# Altium schematic sheet Storage.SchDoc
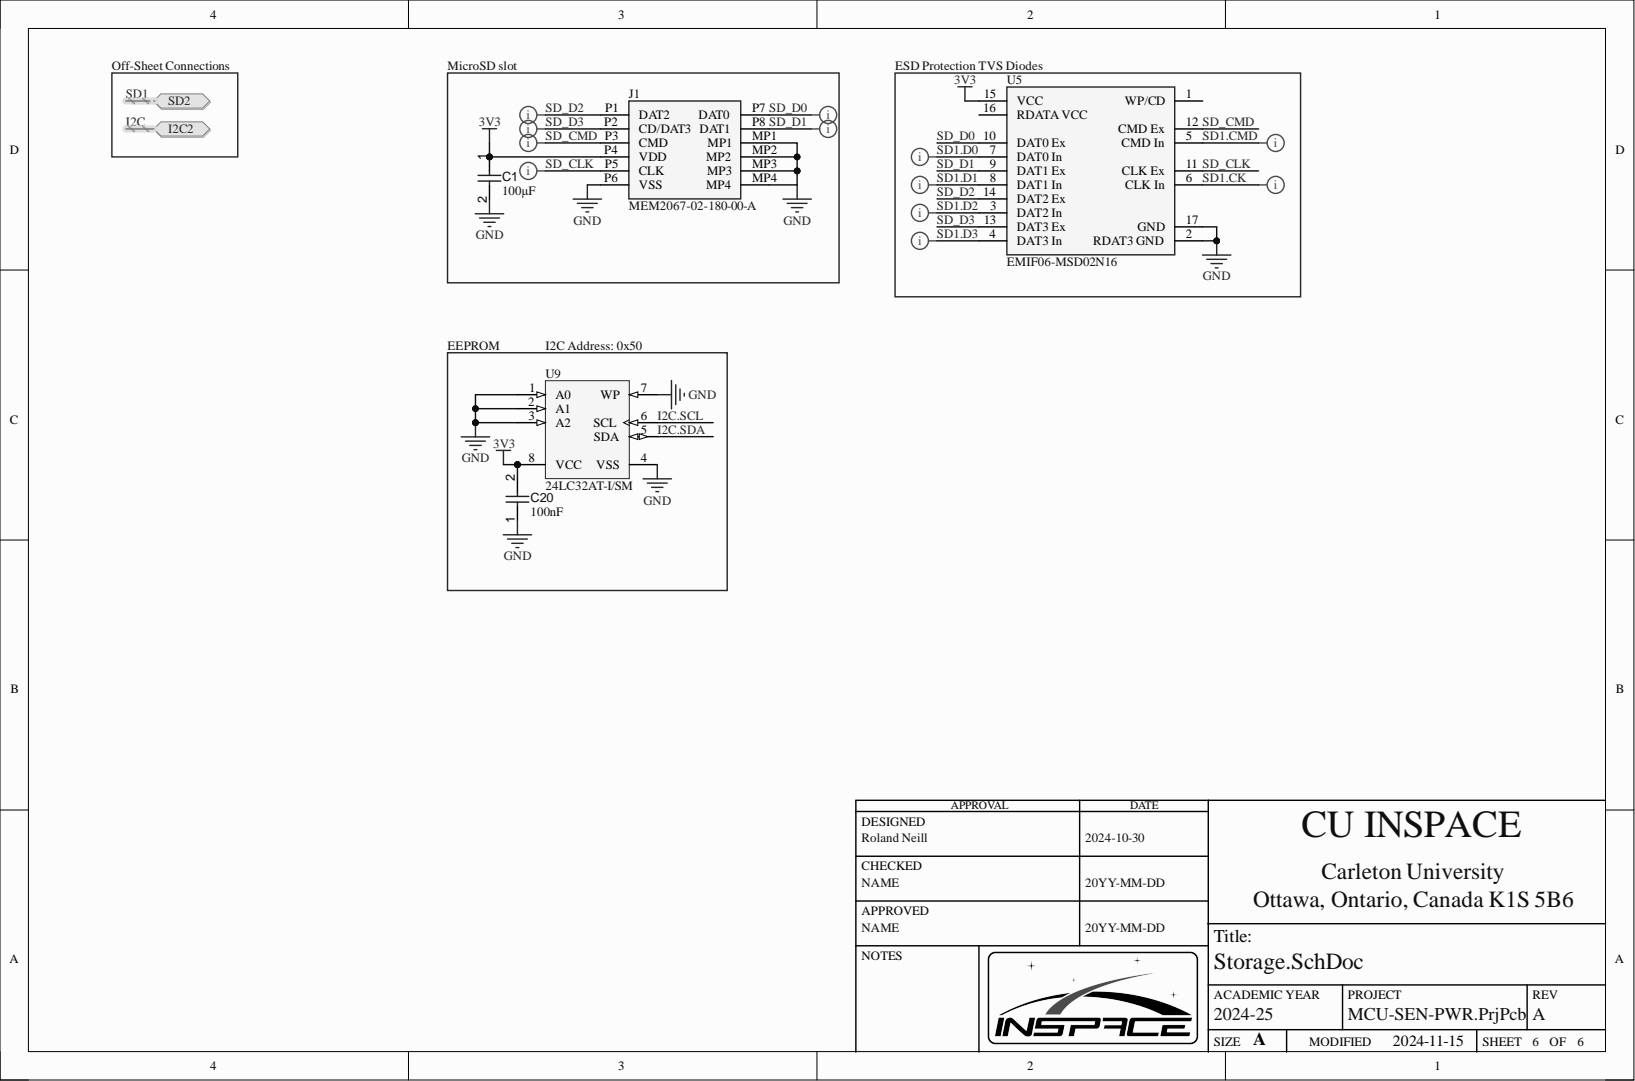
[diagram p0: Storage.SchDoc]

## Parts
C1  value 100µF  [CAPC0805(2012)145_L]  (hidden comment: Capacitor 100µF +/-20% 6.3V 0805)
C20  value 100nF  [CAPC1005X56X25LL10T15]  (hidden comment: Capacitor 0.1 uF +/- 5% 16 V 0402)
J1  MEM2067-02-180-00-A  [MEM2067-02-180-00-A-Footprint-1]
U5  EMIF06-MSD02N16  [FP-EMIF06-MSD02N16-MFG]
U9  24LC32AT-I/SM  [SOIJ-SM8_N]

## Ports
I2C2, I2C2.SCL, I2C2.SDA, SD2, SD2.CK, SD2.CMD, SD2.D0, SD2.D1, SD2.D2, SD2.D3, SD2.D[3..0]

## Nets
3V3: C1.1 C20.2 J1.P4(VDD) U5.15(VCC) U9.8(VCC)
GND: C1.2 C20.1 J1.MP1 J1.MP2 J1.MP3 J1.MP4 J1.P6(VSS) U5.2(RDAT3_GND) U5.17(GND) U9.1(A0) U9.2(A1) U9.3(A2) U9.4(VSS) U9.7(WP)
I2C.SCL: U9.6(SCL) ; port I2C2
I2C.SDA: U9.5(SDA) ; port I2C2
SD1.CK: U5.6(CLK_In) ; port SD2
SD1.CMD: U5.5(CMD_In) ; port SD2
SD1.D0: U5.7(DAT0_In) ; port SD2  [also labelled SD1.D]
SD1.D1: U5.8(DAT1_In) ; port SD2  [also labelled SD1.D]
SD1.D2: U5.3(DAT2_In) ; port SD2  [also labelled SD1.D]
SD1.D3: U5.4(DAT3_In) ; port SD2  [also labelled SD1.D]
SD_CLK: J1.P5(CLK) U5.11(CLK_Ex)
SD_CMD: J1.P3(CMD) U5.12(CMD_Ex)
SD_D0: J1.P7(DAT0) U5.10(DAT0_Ex)
SD_D1: J1.P8(DAT1) U5.9(DAT1_Ex)
SD_D2: J1.P1(DAT2) U5.14(DAT2_Ex)
SD_D3: J1.P2(CD/DAT3) U5.13(DAT3_Ex)

Not connected: U5 1(WP/CD) 16(RDATA_VCC)

## Library data of the parts
C1 = CMP-001-00054-4: Chip Capacitor, 100µF +/-20%, 6.3V, 0805, Thickness 1.45 mm; Tolerance: 10%; Case/Package: 0805; Component Kind: Standard; Max Operating Temperature: 85°C; Min Operating Temperature: -55°C; Mounting Technology: SMT; Pins: 2; Voltage Rating: 6.3V; Library Name: Americas- CU InSpace-  Carleton University
C20 = CMP-001-00001-6: Chip Capacitor, 0.1 uF, +/- 5%, 16 V, 0402 (1005 Metric); Capacitor Type: Ceramic; Voltage Rating: 16V; Case/Package: 0402; Component Kind: Standard; Max Operating Temperature: 125°C; Min Operating Temperature: -55°C; Mounting Technology: SM; Pins: 2; RoHS Compliant: True; Tolerance: 5%; Library Name: Americas- CU InSpace-  Carleton University
J1 = CMP-002-00088-3: PCB Connector, 8 Contact(s), 1 Row(s), Male, Right Angle, 0.04 inch Pitch, Surface Mount Terminal; Component Kind: Standard; Connector Type: PCB; Contact Finish - Mating: GOLD FLASH OVER NICKEL (50); Contact Finish - Termination: Tin (Sn) - with Nickel (Ni) barrier; Contact Material: Copper Alloy; JESD-609 Code: e3; Reach SVHC Compliant: Yes; RoHS Compliant: Yes; Library Name: Americas- CU InSpace-  Carleton University
U5 = CMP-031-00006-1: Data Line Filter, 1 Function(s), 16 Pin(s), Plastic; Channels: 1; Component Kind: Standard; Filter Type: DATA LINE FILTER; Height: 0.55mm; JESD-609 Code: e4; Length: 3.55mm; Max Operating Temperature: 85°C; Min Operating Temperature: -30°C; Mounting Technology: Surface Mount; Package Material: Plastic; Packaging: Tape and Reel; Pins: 16; Reach SVHC Compliant: Yes; RoHS Compliant: Yes; Terminal Finish: Nickel; Library Name: Americas- CU InSpace-  Carleton University
U9 = CMP-019-00058-1: EEPROM, 4KX8, Serial, CMOS, PDSO8; Channels: 1; Clock Frequency: 400kHz; Density: 32768b; Max Supply Voltage: 5.5V; Memory Size: 4096; Memory Width: 8; Min Supply Voltage: 2.5V; Nominal Supply Voltage: 5V; Number of Words Code: 4K; Operating Mode: SYNCHRONOUS; Output Characteristics: OPEN-DRAIN; Package Body Material: Plastic; Qualification Status: Not Qualified; Serial Bus Type: I2C; Time @ Peak Reflow Temperature-Max (s): 40s; Write Cycle Time-Max (tWC): 5ms; Case/Package: SOP; Component Kind: Standard; Height - Seated (Max): 2.03mm; JESD-30 Code: R-PDSO-G8; JESD-609 Code: e3; Length: 5.26mm; Max Operating Temperature: 85°C; Memory Format: EEPROM; Min Operating Temperature: -40°C; Organization: 4KX8; Package Shape: Rectangular; Package Style: SMALL OUTLINEMeter; Parallel/Serial: SERIAL; Peak Reflow Temperature (Cel): 260°C; Pins: 8; Reach SVHC Compliant: Yes; RoHS Compliant: Yes; Surface Mount: Yes; Technology: CMOS; Temperature Grade: INDUSTRIAL; Terminal Finish: Tin; Terminal Form: Gull Wing; Terminal Pitch: 1.27mm; Terminal Position: DUAL; Width: 5.25mm; Library Name: Americas- CU InSpace-  Carleton University
